# Altium schematic sheet SoM.SchDoc(SoM)
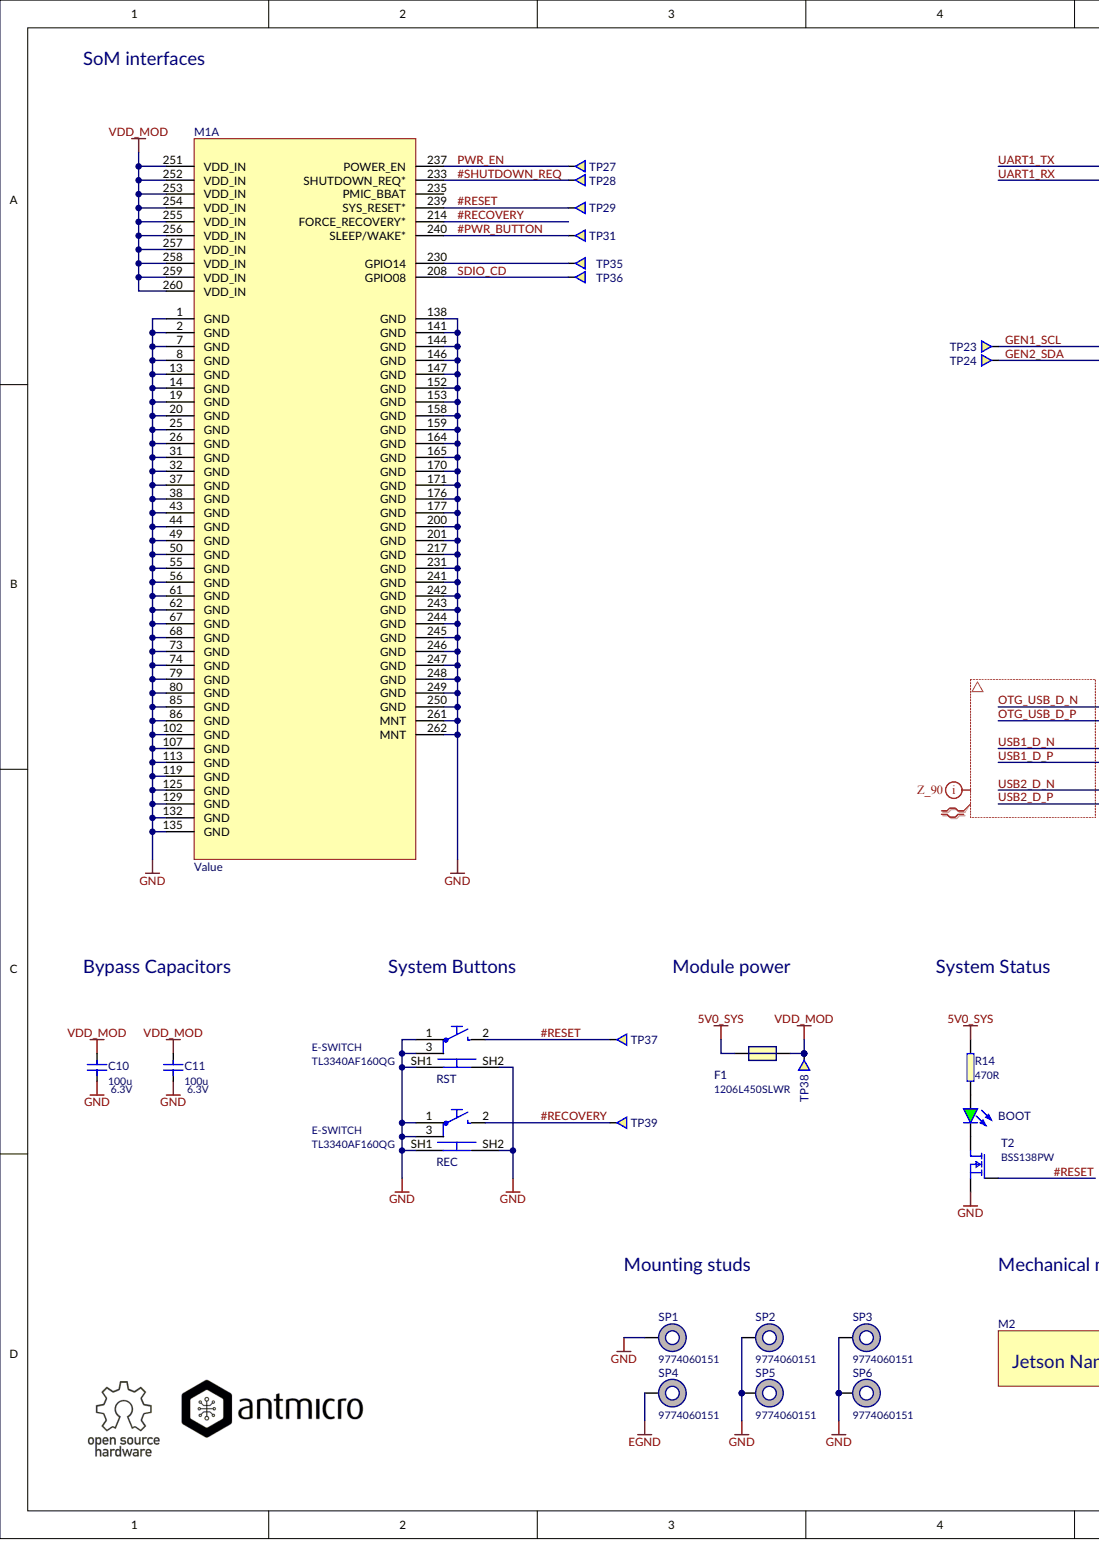
[diagram p0: SoM.SchDoc, left]
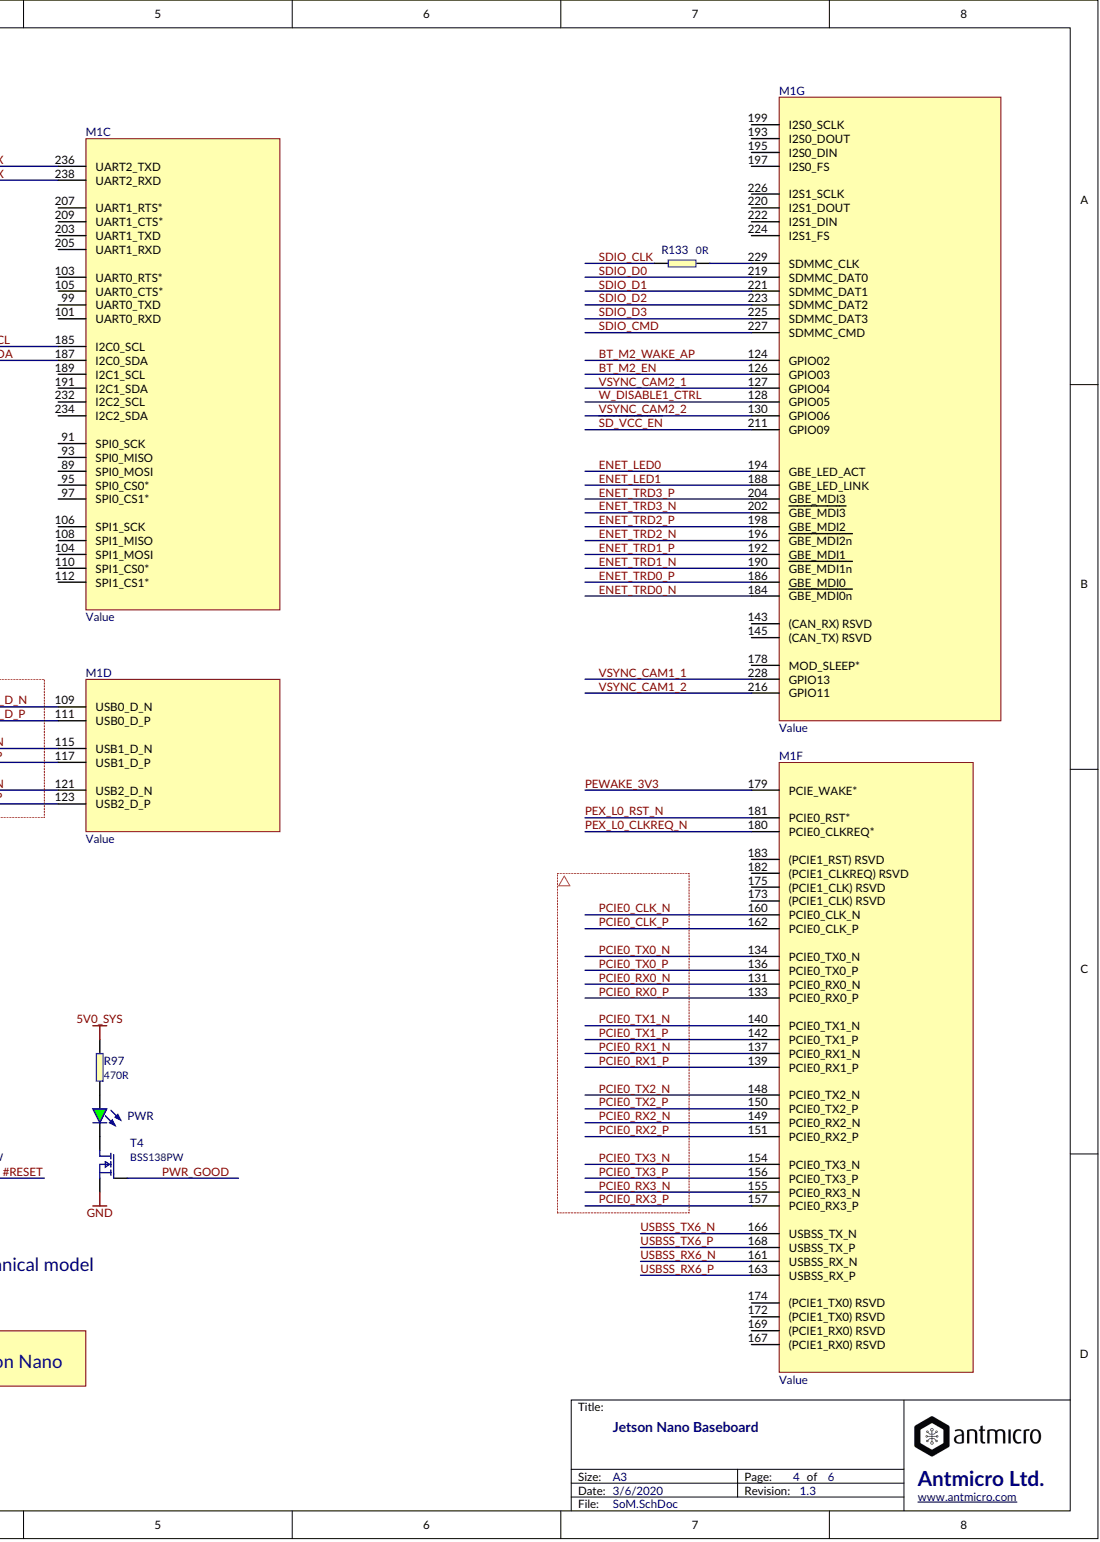
[diagram p1: SoM.SchDoc, right]

## Parts
BOOT  KPT-1608SGC  (value not drawn)
C10  Voltage: 6.3V  (hidden comment: 100u)
C11  Voltage: 6.3V  (hidden comment: 100u)
F1  value 1206L450SLWR  (hidden comment: Value)
M1  =Value  (parts A,C,D,F,G)
M2  =Value
PWR  KPT-1608SGC  (value not drawn)
R14  470R
R97  470R
R133  0R
REC  TL3340AF160QG
RST  TL3340AF160QG
SP1  9774060151
SP2  9774060151
SP3  9774060151
SP4  9774060151
SP5  9774060151
SP6  9774060151
T2  BSS138PW
T4  BSS138PW
TP23  Testpad  (value not drawn)
TP24  Testpad  (value not drawn)
TP27  Testpad  (value not drawn)
TP28  Testpad  (value not drawn)
TP29  Testpad  (value not drawn)
TP31  Testpad  (value not drawn)
TP35  Testpad  (value not drawn)
TP36  Testpad  (value not drawn)
TP37  Testpad  (value not drawn)
TP38  Testpad  (value not drawn)
TP39  Testpad  (value not drawn)

## Nets
5V0_SYS: F1.1 R14.2 R97.2
EGND: SP4.1
GND: C10.1 C11.1 M1.1(GND) M1.2(GND) M1.7(GND) M1.8(GND) M1.13(GND) M1.14(GND) M1.19(GND) M1.20(GND) M1.25(GND) M1.26(GND) M1.31(GND) M1.32(GND) M1.37(GND) M1.38(GND) M1.43(GND) M1.44(GND) M1.49(GND) M1.50(GND) M1.55(GND) M1.56(GND) M1.61(GND) M1.62(GND) M1.67(GND) M1.68(GND) M1.73(GND) M1.74(GND) M1.79(GND) M1.80(GND) M1.85(GND) M1.86(GND) M1.102(GND) M1.107(GND) M1.113(GND) M1.119(GND) M1.125(GND) M1.129(GND) M1.132(GND) M1.135(GND) M1.138(GND) M1.141(GND) M1.144(GND) M1.146(GND) M1.147(GND) M1.152(GND) M1.153(GND) M1.158(GND) M1.159(GND) M1.164(GND) M1.165(GND) M1.170(GND) M1.171(GND) M1.176(GND) M1.177(GND) M1.200(GND) M1.201(GND) M1.217(GND) M1.231(GND) M1.241(GND) M1.242(GND) M1.243(GND) M1.244(GND) M1.245(GND) M1.246(GND) M1.247(GND) M1.248(GND) M1.249(GND) M1.250(GND) M1.261(MNT) M1.262(MNT) REC.1 REC.3 REC.SH1 REC.SH2 RST.1 RST.3 RST.SH1 RST.SH2 SP1.1 SP2.1 SP3.1 SP5.1 SP6.1 T2.2 T4.2
VDD_MOD: C10.2 C11.2 F1.2 M1.251(VDD_IN) M1.252(VDD_IN) M1.253(VDD_IN) M1.254(VDD_IN) M1.255(VDD_IN) M1.256(VDD_IN) M1.257(VDD_IN) M1.258(VDD_IN) M1.259(VDD_IN) M1.260(VDD_IN) TP38.1
#PWR_BUTTON: M1.240(SLEEP/WAKE*) TP31.1
#RECOVERY: M1.214(FORCE_RECOVERY*) REC.2 TP39.1
#RESET: M1.239(SYS_RESET*) RST.2 T2.1 TP29.1 TP37.1
#SHUTDOWN_REQ: M1.233(SHUTDOWN_REQ*) TP28.1
~{PEWAKE_3V3}: M1.179(PCIE_WAKE*)
BT_M2_EN: M1.126(GPIO03)
BT_M2_WAKE_AP: M1.124(GPIO02)
ENET_LED0: M1.194(GBE_LED_ACT)
ENET_LED1: M1.188(GBE_LED_LINK)
ENET_TRD0_N: M1.184(GBE_MDI0n)
ENET_TRD0_P: M1.186(GBE_MDI0)
ENET_TRD1_N: M1.190(GBE_MDI1n)
ENET_TRD1_P: M1.192(GBE_MDI1)
ENET_TRD2_N: M1.196(GBE_MDI2n)
ENET_TRD2_P: M1.198(GBE_MDI2)
ENET_TRD3_N: M1.202(GBE_MDI3)
ENET_TRD3_P: M1.204(GBE_MDI3)
GEN1_SCL: M1.185(I2C0_SCL) TP23.1
GEN2_SDA: M1.187(I2C0_SDA) TP24.1
OTG_USB_D_N: M1.109(USB0_D_N)
OTG_USB_D_P: M1.111(USB0_D_P)
PCIE0_CLK_N: M1.160(PCIE0_CLK_N)
PCIE0_CLK_P: M1.162(PCIE0_CLK_P)
PCIE0_RX0_N: M1.131(PCIE0_RX0_N)
PCIE0_RX0_P: M1.133(PCIE0_RX0_P)
PCIE0_RX1_N: M1.137(PCIE0_RX1_N)
PCIE0_RX1_P: M1.139(PCIE0_RX1_P)
PCIE0_RX2_N: M1.149(PCIE0_RX2_N)
PCIE0_RX2_P: M1.151(PCIE0_RX2_P)
PCIE0_RX3_N: M1.155(PCIE0_RX3_N)
PCIE0_RX3_P: M1.157(PCIE0_RX3_P)
PCIE0_TX0_N: M1.134(PCIE0_TX0_N)
PCIE0_TX0_P: M1.136(PCIE0_TX0_P)
PCIE0_TX1_N: M1.140(PCIE0_TX1_N)
PCIE0_TX1_P: M1.142(PCIE0_TX1_P)
PCIE0_TX2_N: M1.148(PCIE0_TX2_N)
PCIE0_TX2_P: M1.150(PCIE0_TX2_P)
PCIE0_TX3_N: M1.154(PCIE0_TX3_N)
PCIE0_TX3_P: M1.156(PCIE0_TX3_P)
PEX_L0_CLKREQ_N: M1.180(PCIE0_CLKREQ*)
PEX_L0_RST_N: M1.181(PCIE0_RST*)
PWR_EN: M1.237(POWER_EN) TP27.1
PWR_GOOD: T4.1
~{SD_VCC_EN}: M1.211(GPIO09)
SDIO_CD: M1.208(GPIO08) TP36.1
SDIO_CLK: R133.1
SDIO_CMD: M1.227(SDMMC_CMD)
SDIO_D0: M1.219(SDMMC_DAT0)
SDIO_D1: M1.221(SDMMC_DAT1)
SDIO_D2: M1.223(SDMMC_DAT2)
SDIO_D3: M1.225(SDMMC_DAT3)
UART1_RX: M1.238(UART2_RXD)
UART1_TX: M1.236(UART2_TXD)
USB1_D_N: M1.115(USB1_D_N)
USB1_D_P: M1.117(USB1_D_P)
USB2_D_N: M1.121(USB2_D_N)
USB2_D_P: M1.123(USB2_D_P)
USBSS_RX6_N: M1.161(USBSS_RX_N)
USBSS_RX6_P: M1.163(USBSS_RX_P)
USBSS_TX6_N: M1.166(USBSS_TX_N)
USBSS_TX6_P: M1.168(USBSS_TX_P)
VSYNC_CAM1_1: M1.228(GPIO13)
VSYNC_CAM1_2: M1.216(GPIO11)
VSYNC_CAM2_1: M1.127(GPIO04)
VSYNC_CAM2_2: M1.130(GPIO06)
W_DISABLE1_CTRL: M1.128(GPIO05)
NetBOOT_A: BOOT.A R14.1
NetBOOT_K: BOOT.K T2.3
NetM1_229: M1.229(SDMMC_CLK) R133.2
NetM1_230: M1.230(GPIO14) TP35.1
NetPWR_A: PWR.A R97.1
NetPWR_K: PWR.K T4.3
N00387:
N00388:

Not connected: M1 89(SPI0_MOSI) 91(SPI0_SCK) 93(SPI0_MISO) 95(SPI0_CS0*) 97(SPI0_CS1*) 99(UART0_TXD) 101(UART0_RXD) 103(UART0_RTS*) 104(SPI1_MOSI) 105(UART0_CTS*) 106(SPI1_SCK) 108(SPI1_MISO) 110(SPI1_CS0*) 112(SPI1_CS1*) 143((CAN_RX)_RSVD) 145((CAN_TX)_RSVD) 167((PCIE1_RX0)_RSVD) 169((PCIE1_RX0)_RSVD) 172((PCIE1_TX0)_RSVD) 173((PCIE1_CLK)_RSVD) 174((PCIE1_TX0)_RSVD) 175((PCIE1_CLK)_RSVD) 178(MOD_SLEEP*) 182((PCIE1_CLKREQ)_RSVD) 183((PCIE1_RST)_RSVD) 189(I2C1_SCL) 191(I2C1_SDA) 193(I2S0_DOUT) 195(I2S0_DIN) 197(I2S0_FS) 199(I2S0_SCLK) 203(UART1_TXD) 205(UART1_RXD) 207(UART1_RTS*) 209(UART1_CTS*) 220(I2S1_DOUT) 222(I2S1_DIN) 224(I2S1_FS) 226(I2S1_SCLK) 232(I2C2_SCL) 234(I2C2_SDA) 235(PMIC_BBAT)

## Library data of the parts
BOOT PWR = (no symbol name): PartNumber: LED_G; Manufacturer: KINGBRIGHT; Value: KPT-1608SGC; PartSymbol: KPT-1608SGC
C10 C11 = (no symbol name): PartNumber: C_100u_1206; Manufacturer: VISHAY; PartSymbol: TMCMA0J107MTRF; Value: 100u
F1 = (no symbol name): PartNumber: LITTELFUSE_1206L450SLWR; Manufacturer: LITTELFUSE; PartSymbol: 1206L450SLWR
M1 = (no symbol name): PartNumber: jetson-nano-new-release
M2 = (no symbol name): PartNumber: jetson-nano-module
R14 R97 = (no symbol name): PartNumber: R_470R_0402; Manufacturer: VISHAY; PartSymbol: CRCW0402470RFKED.; Value: 470R
R133 = (no symbol name): PartNumber: R_0R_0402; Manufacturer: Panasonic; PartSymbol: ERJ2GE0R00X; Value: 0R
REC RST = (no symbol name): Manufacturer: E-SWITCH; Value: TL3340AF160QG; PartNumber: TL3340AF160QG; PartSymbol: TL3340AF160QG
SP1-SP6 = (no symbol name): PartNumber: M2.5x6; Manufacturer: WURTH ELEKTRONIK; Value: 9774060151; PartSymbol: 9774060151
T2 T4 = (no symbol name): Manufacturer: NXP; Value: BSS138PW; PartNumber: BSS138PW; PartSymbol: BSS138PW
TP23 TP24 TP27-TP29 TP31 TP35-TP39 = (no symbol name): PartNumber: TP-R-1.5
